# Altium schematic sheet STM32F103RB最小系统.SchDoc
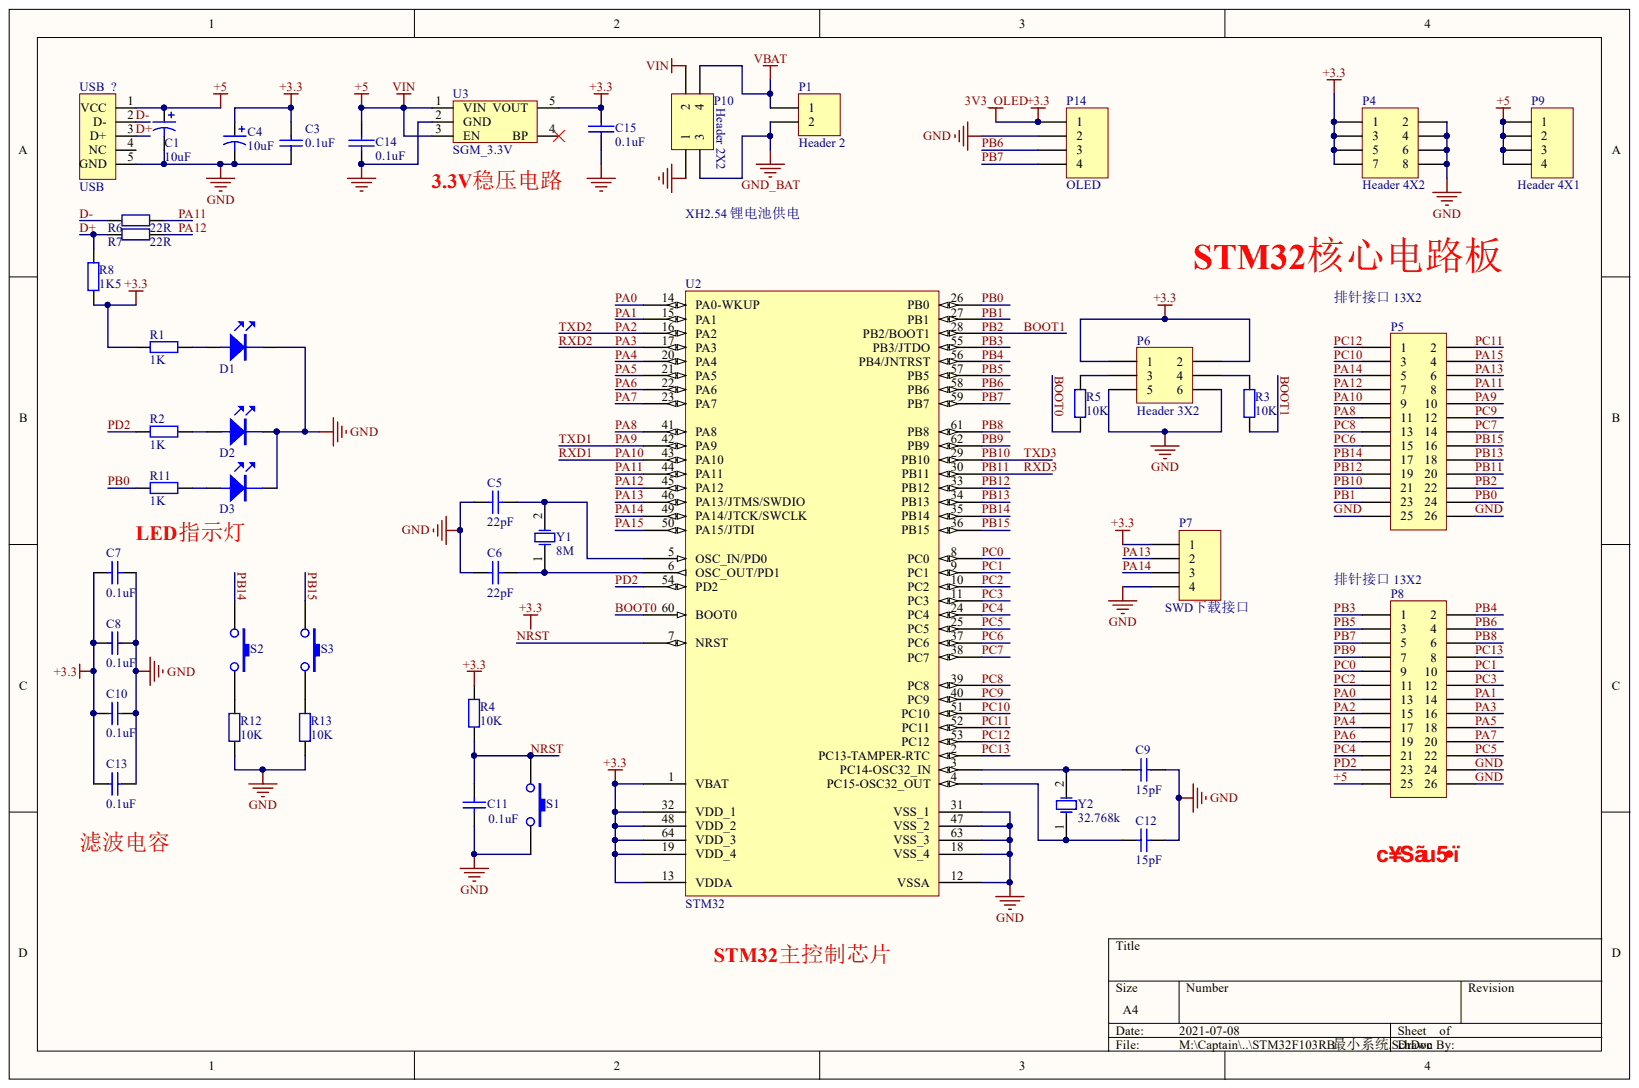
[diagram p0: STM32F103RB最小系统.SchDoc]

## Parts
C1  10uF  [0603C]
C3  0.1uF  [0603C]
C4  10uF  [0603C]
C5  22pF  [0603C]
C6  22pF  [0603C]
C7  0.1uF  [0603C]
C8  0.1uF  [0603C]
C9  15pF  [0603C]
C10  0.1uF  [0603C]
C11  0.1uF  [0603C]
C12  15pF  [0603C]
C13  0.1uF  [0603C]
C14  0.1uF  [0603C]
C15  0.1uF  [0603C]
D1  LED  [LED0805]  (value not drawn)
D2  LED  [LED0805]  (value not drawn)
D3  LED  [LED0805]  (value not drawn)
P1  Header 2  [HDR1X2]
P4  Header 4X2  [HDR2X4]
P5  排针接口 13X2  [HDR2X13]
P6  Header 3X2  [HDR2X3]
P7  SWD下载接口  [HDR1X4]
P8  排针接口 13X2  [HDR2X13]
P9  Header 4X1  [HDR1X4]
P10  Header 2X2  [HDR2X2]
P14  OLED  [HDR1X4]
R1  1K  [0603R]
R2  1K  [0603R]
R3  10K  [0603R]
R4  10K  [0603R]
R5  10K  [0603R]
R6  22R  [0603R]
R7  22R  [0603R]
R8  1K5  [0603R]
R11  1K  [0603R]
R12  10K  [0603R]
R13  10K  [0603R]
S1  KEY  [KEY-XG]  (value not drawn)
S2  KEY  [KEY-XG]  (value not drawn)
S3  KEY  [KEY-XG]  (value not drawn)
U2  STM32  [LQFP64_N]
U3  SGM_3.3V  [SOT-23-5]
USB_?  USB  [USB_T]
Y1  8M  [OSC2PR-1]
Y2  32.768k  [XTAL-B]

## Nets
+3.3: C3.2 C4.1 C7.1 C8.1 C10.1 C13.1 C15.2 P4.1 P4.3 P4.5 P4.7 P6.1 P6.2 P7.1 P14.1 R1.1 R4.2 R8.1 U2.1(VBAT) U2.13(VDDA) U2.19(VDD_4) U2.32(VDD_1) U2.48(VDD_2) U2.64(VDD_3) U3.5(VOUT)
GND_BAT: P1.2 P10.3
VBAT: P1.1 P10.4
+5: C1.1 C14.2 P8.25 P9.1 P9.2 P9.3 P9.4 P10.2 U3.1(VIN) U3.3(EN) USB_?.1(VCC)
BOOT0: R5.2 U2.60(BOOT0)
BOOT1: P5.22 R3.1 U2.28(PB2/BOOT1)
D+: R7.1 R8.2 USB_?.3(D+)
D-: R6.1 USB_?.2(D-)
GND: C1.2 C3.1 C4.2 C5.1 C6.1 C7.2 C8.2 C9.2 C10.2 C11.1 C12.2 C13.2 C14.1 C15.1 D1.2 D2.2 D3.2 P4.2 P4.4 P4.6 P4.8 P5.25 P5.26 P6.5 P6.6 P7.4 P8.24 P8.26 P10.1 P14.2 R12.1 R13.1 S1.2 U2.12(VSSA) U2.18(VSS_4) U2.31(VSS_1) U2.47(VSS_2) U2.63(VSS_3) U3.2(GND) USB_?.5(GND)
NRST: C11.2 R4.1 S1.1 U2.7(NRST)
PA0: P8.13 U2.14(PA0-WKUP)
PA1: P8.14 U2.15(PA1)
PA2: P8.15 U2.16(PA2)
PA3: P8.16 U2.17(PA3)
PA4: P8.17 U2.20(PA4)
PA5: P8.18 U2.21(PA5)
PA6: P8.19 U2.22(PA6)
PA7: P8.20 U2.23(PA7)
PA8: P5.11 U2.41(PA8)
PA9: P5.10 U2.42(PA9)
PA10: P5.9 U2.43(PA10)
PA11: P5.8 R6.2 U2.44(PA11)
PA12: P5.7 R7.2 U2.45(PA12)
PA13: P5.6 P7.2 U2.46(PA13/JTMS/SWDIO)
PA14: P5.5 P7.3 U2.49(PA14/JTCK/SWCLK)
PA15: P5.4 U2.50(PA15/JTDI)
PB0: P5.24 R11.1 U2.26(PB0)
PB1: P5.23 U2.27(PB1)
PB3: P8.1 U2.55(PB3/JTDO)
PB4: P8.2 U2.56(PB4/JNTRST)
PB5: P8.3 U2.57(PB5)
PB6: P8.4 P14.3 U2.58(PB6)
PB7: P8.5 P14.4 U2.59(PB7)
PB8: P8.6 U2.61(PB8)
PB9: P8.7 U2.62(PB9)
PB10: P5.21 U2.29(PB10)
PB11: P5.20 U2.30(PB11)
PB12: P5.19 U2.33(PB12)
PB13: P5.18 U2.34(PB13)
PB14: P5.17 S2.1 U2.35(PB14)
PB15: P5.16 S3.1 U2.36(PB15)
PC0: P8.9 U2.8(PC0)
PC1: P8.10 U2.9(PC1)
PC2: P8.11 U2.10(PC2)
PC3: P8.12 U2.11(PC3)
PC4: P8.21 U2.24(PC4)
PC5: P8.22 U2.25(PC5)
PC6: P5.15 U2.37(PC6)
PC7: P5.14 U2.38(PC7)
PC8: P5.13 U2.39(PC8)
PC9: P5.12 U2.40(PC9)
PC10: P5.3 U2.51(PC10)
PC11: P5.2 U2.52(PC11)
PC12: P5.1 U2.53(PC12)
PC13: P8.8 U2.2(PC13-TAMPER-RTC)
PD2: P8.23 R2.1 U2.54(PD2)
NetC5_2: C5.2 U2.5(OSC_IN/PD0) Y1.2
NetC6_2: C6.2 U2.6(OSC_OUT/PD1) Y1.1
NetC9_1: C9.1 U2.3(PC14-OSC32_IN) Y2.2
NetC12_1: C12.1 U2.4(PC15-OSC32_OUT) Y2.1
NetD1_1: D1.1 R1.2
NetD2_1: D2.1 R2.2
NetD3_1: D3.1 R11.2
NetP6_3: P6.3 R5.1
NetP6_4: P6.4 R3.2
NetR12_2: R12.2 S2.2
NetR13_2: R13.2 S3.2

Not connected: U3 4(BP); USB_? 4(NC)

## Library data of the parts
C1 C4 = Cap Pol1: Polarized Capacitor (Radial); Component Kind: Standard; LatestRevisionDate: 17-Jul-2002; LatestRevisionNote: Re-released for DXP Platform.; Library Name: Miscellaneous Devices.IntLib; PackageReference: RB7.6-15; Published: 8-Jun-2000; Value: 10uF; Publisher: Altium Limited
C3 C5-C13 = Cap: Capacitor; Component Kind: Standard; LatestRevisionDate: 17-Jul-2002; LatestRevisionNote: Re-released for DXP Platform.; Library Name: Miscellaneous Devices.IntLib; PackageReference: RAD-0.3; Published: 8-Jun-2000; Simulation: CAP; Publisher: Altium Limited
  C3 C7 C8 C10 C11 C13: Value: 0.1uF
  C5 C6: Value: 22pF
  C9 C12: Value: 15pF
C14 C15 = CAP: Capacitor; Component Kind: Standard
P1 = Header 2: Header, 2-Pin; Component Kind: Standard; LatestRevisionDate: 17-Jul-2002; LatestRevisionNote: Re-released for DXP Platform.; Library Name: Miscellaneous Connectors.IntLib; Publisher: Altium Limited
P4 = Header 4X2: Header, 4-Pin, Dual row; Component Kind: Standard; LatestRevisionDate: 17-Jul-2002; LatestRevisionNote: Re-released for DXP Platform.; Library Name: Miscellaneous Connectors.IntLib; Publisher: Altium Limited
P5 P8 = Header 13X2: Header, 13-Pin, Dual row; Component Kind: Standard; LatestRevisionDate: 17-Jul-2002; LatestRevisionNote: Re-released for DXP Platform.; Library Name: Miscellaneous Connectors.IntLib; Publisher: Altium Limited
P6 = Header 3X2: Header, 3-Pin, Dual row; Component Kind: Standard; LatestRevisionDate: 17-Jul-2002; LatestRevisionNote: Re-released for DXP Platform.; Library Name: Miscellaneous Connectors.IntLib; Publisher: Altium Limited
P7 P9 P14 = Header 4: Header, 4-Pin; Component Kind: Standard; LatestRevisionDate: 17-Jul-2002; LatestRevisionNote: Re-released for DXP Platform.; Library Name: Miscellaneous Connectors.IntLib; Publisher: Altium Limited
P10 = Header 2X2: Header, 2-Pin, Dual row; Component Kind: Standard; LatestRevisionDate: 17-Jul-2002; LatestRevisionNote: Re-released for DXP Platform.; Library Name: Miscellaneous Connectors.IntLib; Publisher: Altium Limited
R1 R2 R4 R11-R13 = Res2: Resistor; Component Kind: Standard; LatestRevisionDate: 17-Jul-2002; LatestRevisionNote: Re-released for DXP Platform.; Library Name: Miscellaneous Devices.IntLib; PackageReference: AXIAL-0.4; Published: 8-Jun-2000; Simulation: RESISTOR; Publisher: Altium Limited
  R1 R2 R11: Value: 1K
  R4 R12 R13: Value: 10K
R3 R5-R8 = Res2: Resistor; Value: 1K; Component Kind: Standard; LatestRevisionDate: 17-Jul-2002; LatestRevisionNote: Re-released for DXP Platform.; Library Name: Miscellaneous Devices.IntLib; PackageReference: AXIAL-0.4; Published: 8-Jun-2000; Simulation: RESISTOR; Publisher: Altium Limited
U2 = STM32F103RBT6: STM32 ARM-based 32-bit MCU with 128 Kbytes Flash, 64-pin LQFP, Industrial Temperature; Component Kind: Standard; ComponentLink1Description: Manufacturer Link; ComponentLink1URL: www.st.com/stm32; ComponentLink2Description: Datasheet; ComponentLink2URL: http://www.st.com/stonline/products/literature/ds/13587.pdf; DatasheetVersion: rev6, Mar-2008; Library Name: ST Microcontroller 32-Bit STM32.IntLib; PackageDescription: 64-Pin Low-Profile Quad Flat Pack, 10 x 10 mm Body, 0.5 mm Pitch (LQFP64); PackageReference: LQFP64; PackageVersion: Mar-2008; Published: 30-May-2008; Publisher: Altium Limited
U3 = RT9193-33: 3.3V超低压差LDO; Component Kind: Standard
USB_? = USB5: Component Kind: Standard; Library Name: DF_AD.SCHLIB
Y1 Y2 = XTAL: Crystal Oscillator; Component Kind: Standard; LatestRevisionDate: 17-Jul-2002; LatestRevisionNote: Re-released for DXP Platform.; Library Name: Miscellaneous Devices.IntLib; PackageReference: R38; Published: 8-Jun-2000; Simulation: XTAL; Publisher: Altium Limited
D1-D3 = LED: Component Kind: Standard; Library Name: CCDXPLIB.IntLib
S1-S3 = KEY: Component Kind: Standard; Library Name: CCDXPLIB.IntLib
